# Altium schematic sheet SH1 - CAN.SchDoc
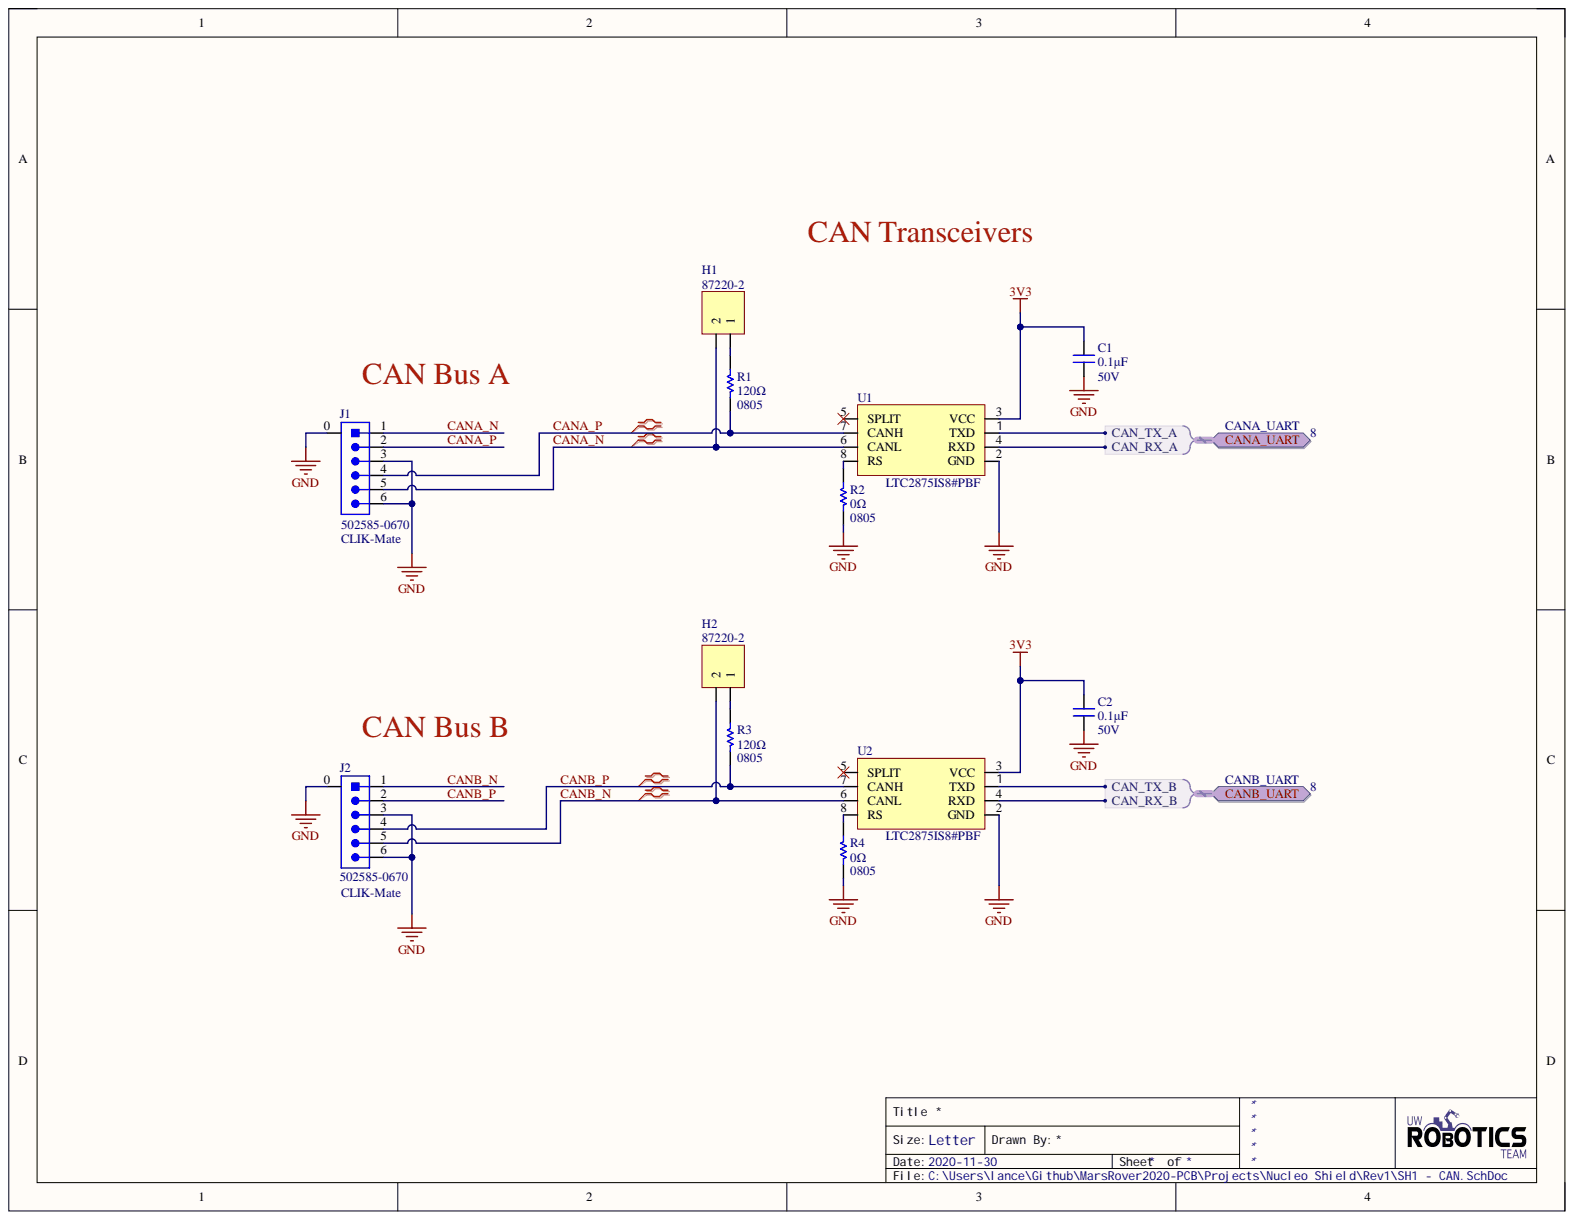
[diagram p0: SH1 - CAN.SchDoc]

## Parts
C1  value 0.1μF  Voltage Rating: 50V  [CAP2012]  (hidden comment: CAP_0.1uF_50V_0805)
C2  value 0.1μF  Voltage Rating: 50V  [CAP2012]  (hidden comment: CAP_0.1uF_50V_0805)
H1  Manufacturer Part Number 1: 87220-2  [HDR1X2]  (hidden comment: JUMPER_2)
H2  Manufacturer Part Number 1: 87220-2  [HDR1X2]  (hidden comment: JUMPER_2)
J1  Family: CLIK-Mate  Manufactor Number: 502585-0670  [MOLEX-5025850670]  (hidden comment: CON_6M_SM_RA_1.5mm)
J2  Family: CLIK-Mate  Manufactor Number: 502585-0670  [MOLEX-5025850670]  (hidden comment: CON_6M_SM_RA_1.5mm)
R1  Case Code (Imperial): 0805  Resistance: 120Ω  [RESC0805]  (hidden comment: RES_120Ω_0805_1%)
R2  value 0Ω  Case Code (Imperial): 0805  [RESC0805]  (hidden comment: RES_0Ω_0805)
R3  Case Code (Imperial): 0805  Resistance: 120Ω  [RESC0805]  (hidden comment: RES_120Ω_0805_1%)
R4  value 0Ω  Case Code (Imperial): 0805  [RESC0805]  (hidden comment: RES_0Ω_0805)
U1  Manufacturer Part Number 1: LTC2875IS8#PBF  [LT-S8_N]  (hidden comment: IC TXRX CAN)
U2  Manufacturer Part Number 1: LTC2875IS8#PBF  [LT-S8_N]  (hidden comment: IC TXRX CAN)

## Ports
CANA_UART, CANA_UART.CAN_RX_A, CANA_UART.CAN_TX_A, CANB_UART, CANB_UART.CAN_RX_B, CANB_UART.CAN_TX_B

## Nets
3V3: C1.1 C2.1 U1.3(VCC) U2.3(VCC)
GND: C1.2 C2.2 J1.0 J1.3 J1.6 J2.0 J2.3 J2.6 R2.1 R4.1 U1.2(GND) U2.2(GND)
CANA_N: H1.2 J1.1 J1.5 U1.6(CANL)
CANA_P: J1.2 J1.4 R1.1 U1.7(CANH)
CANB_N: H2.2 J2.1 J2.5 U2.6(CANL)
CANB_P: J2.2 J2.4 R3.1 U2.7(CANH)
NetH1_1: H1.1 R1.2
NetH2_1: H2.1 R3.2
NetR2_2: R2.2 U1.8(RS)
NetR4_2: R4.2 U2.8(RS)
NetU1_1: U1.1(TXD) ; port CANA_UART
NetU1_4: U1.4(RXD) ; port CANA_UART
NetU2_1: U2.1(TXD) ; port CANB_UART
NetU2_4: U2.4(RXD) ; port CANB_UART

Not connected: U1 5(SPLIT); U2 5(SPLIT)

## Library data of the parts
C1 C2 = CAP_0.1uF_50V_0805: CAP CER 0.1UF 50V X7R 0805; Manufacturer 1: Murata Electronics; Manufacturer Part Number 1: GCD21BR71H104KA01L; Tolerance: 10%; Case Code (Imperial): 0805; Case Code (Metric): 2012; Component Kind: Standard; Depth: 1.25mm; Dielectric: X7R; Height: 1.25mm; Length: 2mm; Max Operating Temperature: 125°C; Min Operating Temperature: -55°C; Mount: Surface Mount; Package: 0805 (2012 Metric); Ratings: AEC-Q200; Supplier 1: Digi-Key; Supplier Part Number 1: 490-11955-1-ND; Termination: SMD/SMT; Thickness: 0.049inch; Width: 0.049inch; Library Name: UWRT_Schematic_Library_2020.SchLib
H1 H2 = JUMPER_2: HEADER 2X1; Manufacturer 1: TE Connectivity; Component Kind: Standard; Mount: Through Hole; Supplier 1: Digi-Key; Supplier Part Number 1: A26542-ND; Library Name: UWRT_Schematic_Library_2020.SchLib
J1 J2 = CON_6M_SM_RA_1.5mm: CONN HEADER SMD RIGHT ANGLE 1.5 MM PITCH; Manufacturer: Molex; Component Kind: Standard; Supplier 1: Digi-Key; Supplier Part Number 1: WM12832CT-ND; Library Name: UWRT_Schematic_Library_2020.SchLib
R1 R3 = RES_120Ω_0805_1%: RES 120 OHM 1% 1/8W 0805; Manufacturer 1: Vishay; Manufacturer Part Number 1: CRCW0805120RFKEA; Power Rating: 125mW; Voltage Rating: 150V; Component Kind: Standard; Mount: Surface Mount; Supplier 1: Digi-Key; Supplier Part Number 1: 541-120CCT-ND; Library Name: UWRT_Schematic_Library_2020.SchLib
R2 R4 = RES_0Ω_0805: RES 0 OHM JUMPER 1/8W 0805; Manufacturer 1: Panasonic Electronic Components; Manufacturer Part Number 1: ERJ-6GEY0R00V; Component Kind: Standard; Mount: Surface Mount; Supplier 1: Digi-Key; Supplier Part Number 1: P0.0ACT-ND; Library Name: UWRT_Schematic_Library_2020.SchLib
U1 U2 = LTC2875: IC TXRX CAN 4MBPS 8SO; Manufacturer 1: Linear Technologies; Component Kind: Standard; Mount: Surface Mount; Package: 8-SOIC (0.154", 3.90mm Width); Supplier 1: Digi-Key; Supplier Part Number 1: LTC2875IS8#PBF-ND; Library Name: UWRT_Schematic_Library_2020.SchLib
